# Altium schematic sheet kit_V5_borad.SchDoc
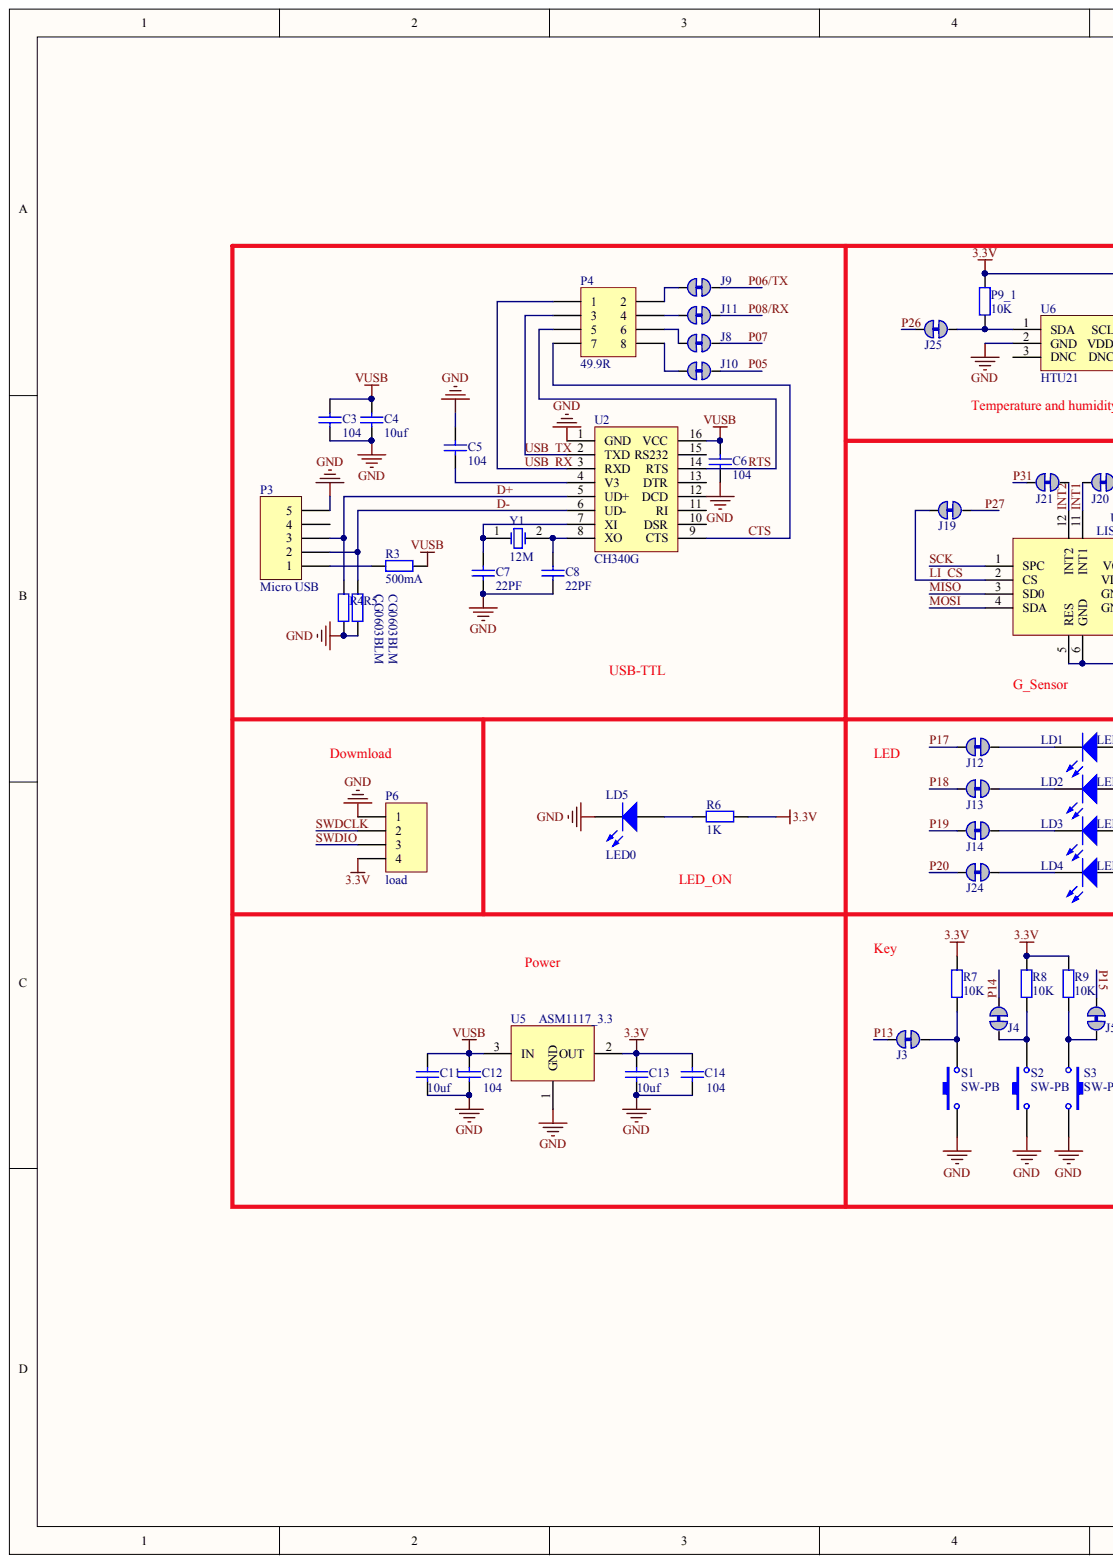
[diagram p0: kit_V5_borad.SchDoc, left]
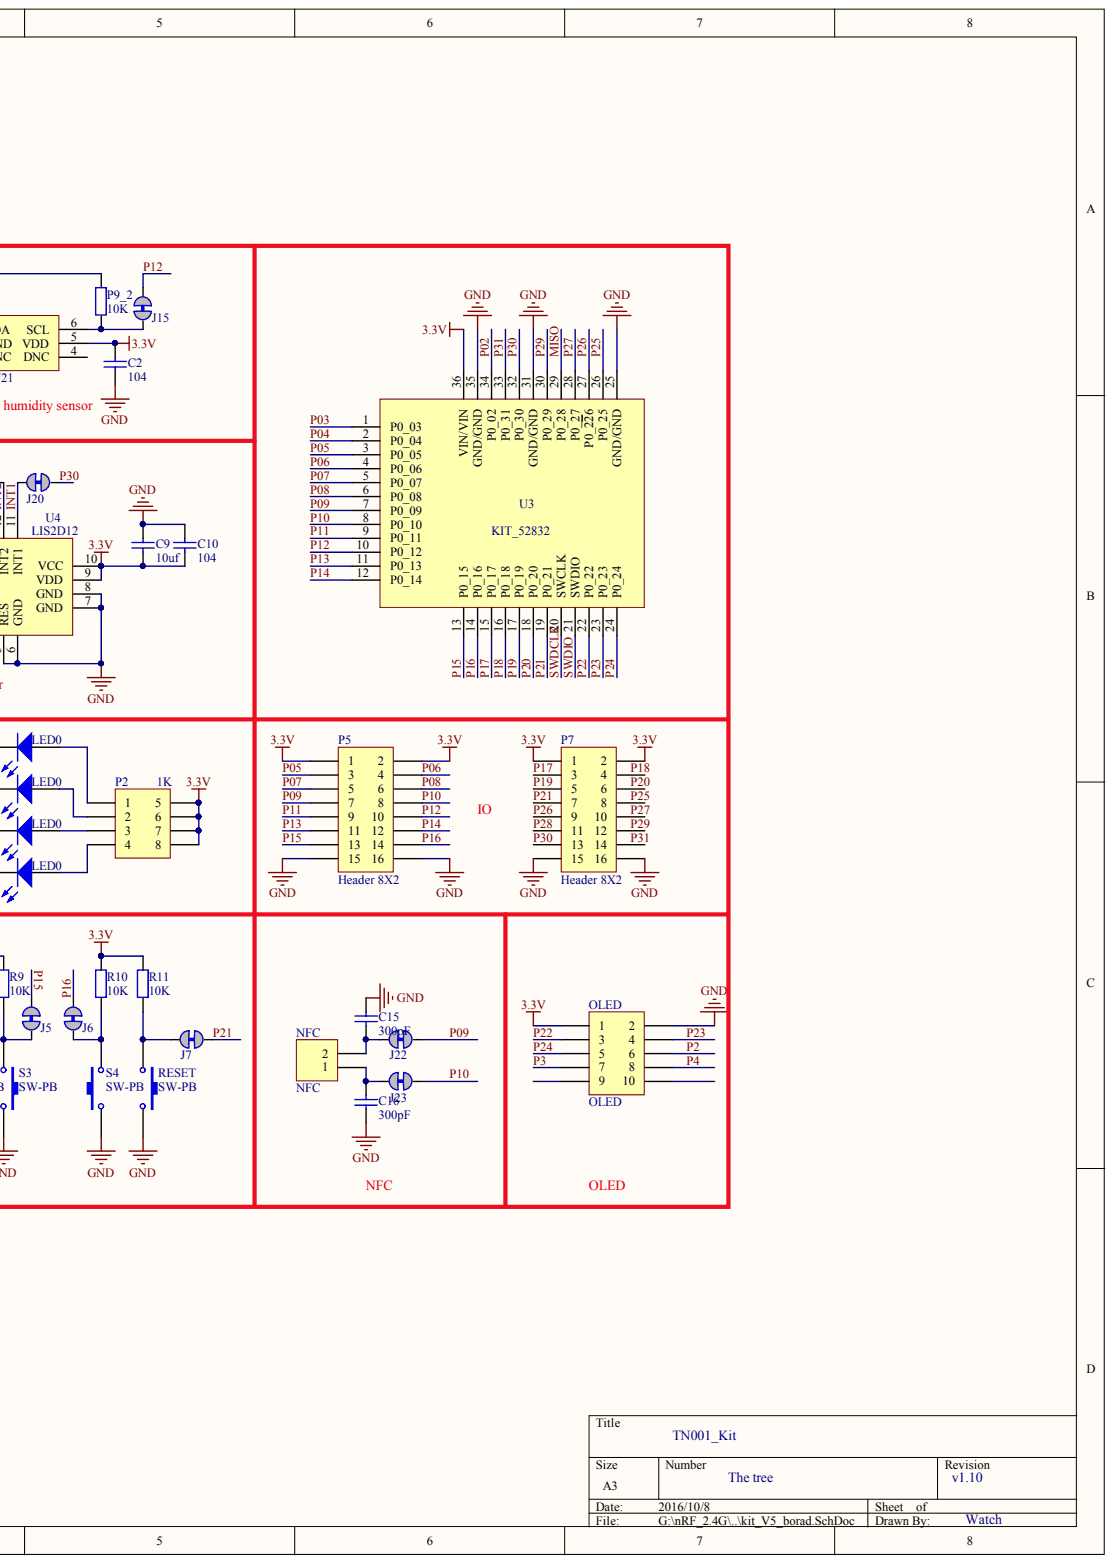
[diagram p1: kit_V5_borad.SchDoc, right]

## Parts
C2  104  [0603C]
C3  104  [0603C]
C4  10uf  [0603C]
C5  104  [0603C]
C6  104  [0603C]
C7  22PF  [0603C]
C8  22PF  [0603C]
C9  10uf  [0603C]
C10  104  [0603C]
C11  10uf  [0603C]
C12  104  [0603C]
C13  10uf  [0603C]
C14  104  [0603C]
C15  300pF  [RAD-0.3]
C16  300pF  [RAD-0.3]
J3  Component_1  (value not drawn)
J4  Component_1  (value not drawn)
J5  Component_1  (value not drawn)
J6  Component_1  (value not drawn)
J7  Component_1  (value not drawn)
J8  Component_1  (value not drawn)
J9  Component_1  (value not drawn)
J10  Component_1  (value not drawn)
J11  Component_1  (value not drawn)
J12  Component_1  (value not drawn)
J13  Component_1  (value not drawn)
J14  Component_1  (value not drawn)
J15  Component_1  (value not drawn)
J19  Component_1  (value not drawn)
J20  Component_1  (value not drawn)
J21  Component_1  (value not drawn)
J22  Component_1  (value not drawn)
J23  Component_1  (value not drawn)
J24  Component_1  (value not drawn)
J25  Component_1  (value not drawn)
LD1  LED0  [LED_0805]
LD2  LED0  [LED_0805]
LD3  LED0  [LED_0805]
LD4  LED0  [LED_0805]
LD5  LED0  [LED_0805]
NFC  NFC  [HDR1X2]
OLED  OLED  [CON5*2]
P2  1K  [0603RA]
P3  Micro USB  [USB-MICRO-5P-2]
P4  49.9R  [HDR2X4]
P5  Header 8X2  [CON8*2]
P6  load  [2.54_CON4_W]
P7  Header 8X2  [CON8*2]
P9_1  10K  [AXIAL-0.4]
P9_2  10K  [AXIAL-0.4]
R3  500mA  [1812]
R4  CG0603BLM  [0603R]
R5  CG0603BLM  [0603R]
R6  1K  [0603R]
R7  10K  [0603R]
R8  10K  [0603R]
R9  10K  [0603R]
R10  10K  [0603R]
R11  10K  [0603R]
RESET  SW-PB  [KEY_SOP_2]
S1  SW-PB  [KEY_SOP_2]
S2  SW-PB  [KEY_SOP_2]
S3  SW-PB  [KEY_SOP_2]
S4  SW-PB  [KEY_SOP_2]
U2  CH340G  [SOP16]
U3  KIT_52832
U4  LIS2D12  [LGA12_2*2]
U5  ASM1117_3.3  [SOT223]
U6  HTU21
Y1  12M  [JZ_SOP]

## Nets
3.3V: C2.1 C9.1 C10.1 C13.2 C14.2 OLED.1 P2.5 P2.6 P2.7 P2.8 P5.1 P5.2 P6.4 P7.1 P7.2 P9_1.1 P9_2.1 R6.2 R7.2 R8.2 R9.2 R10.2 R11.2 U3.36(VIN/VIN) U4.9(VDD) U4.10(VCC) U5.2 U6.5(VDD)
GND: C2.2 C3.2 C4.1 C5.2 C6.1 C7.1 C8.1 C9.2 C10.2 C11.1 C12.1 C13.1 C14.1 C15.2 C16.1 LD5.2 OLED.2 P3.5 P5.15 P5.16 P6.1 P7.15 P7.16 R4.1 R5.1 RESET.2 S1.1 S2.1 S3.2 S4.1 U2.1(GND) U3.25(GND/GND) U3.31(GND/GND) U3.35(GND/GND) U4.5(RES) U4.6(GND) U4.7(GND) U4.8(GND) U5.1 U6.2(GND)
VUSB: C3.1 C4.2 C6.2 C11.2 C12.2 R3.2 U2.16(VCC) U5.3
CTS: P4.7 U2.9(CTS)
D+: P3.3 R4.2 U2.5(UD+)
D-: P3.2 R5.2 U2.6(UD-)
INT1: J20.2 U4.11(INT1)
INT2: J21.1 U4.12(INT2)
LI_CS: J19.1 U4.2(CS)
MISO: U3.29(P0_28) U4.3(SD0)
MOSI: U4.4(SDA)
P02: U3.34(P0_02)
P2: OLED.6
P03: U3.1(P0_03)
P3: OLED.7
P04: U3.2(P0_04)
P4: OLED.8
P05: J10.1 P5.3 U3.3(P0_05)
P06: P5.4 U3.4(P0_06)
P06/TX: J9.1
P07: J8.1 P5.5 U3.5(P0_07)
P08: P5.6 U3.6(P0_08)
P08/RX: J11.1
P09: J22.1 P5.7 U3.7(P0_09)
P10: J23.1 P5.8 U3.8(P0_10)
P11: P5.9 U3.9(P0_11)
P12: J15.2 P5.10 U3.10(P0_12)
P13: J3.2 P5.11 U3.11(P0_13)
P14: J4.1 P5.12 U3.12(P0_14)
P15: J5.1 P5.13 U3.13(P0_15)
P16: J6.1 P5.14 U3.14(P0_16)
P17: J12.2 P7.3 U3.15(P0_17)
P18: J13.2 U3.16(P0_18)
P19: J14.2 P7.5 U3.17(P0_19)
P20: J24.2 U3.18(P0_20)
P21: J7.2 P7.7 U3.19(P0_21)
P22: OLED.3 U3.22(P0_22)
P23: OLED.4 U3.23(P0_23)
P24: OLED.5 U3.24(P0_24)
P25: U3.26(P0_25)
P26: J25.2 P7.9 U3.27(P0_226)
P27: J19.2 U3.28(P0_27)
P28: P7.11
P29: U3.30(P0_29)
P30: J20.1 P7.13 U3.32(P0_30)
P31: J21.2 U3.33(P0_31)
RTS: P4.5 U2.14(RTS)
SCK: U4.1(SPC)
SWDCLK: P6.2 U3.20(SWCLK)
SWDIO: P6.3 U3.21(SWDIO)
USB_RX: P4.1 U2.3(RXD)
USB_TX: P4.3 U2.2(TXD)
NetC5_1: C5.1 U2.4(V3)
NetC7_2: C7.2 U2.7(XI) Y1.1
NetC8_2: C8.2 U2.8(XO) Y1.2
NetC15_1: C15.1 J22.2 NFC.2
NetC16_2: C16.2 J23.2 NFC.1
NetJ3_1: J3.1 R7.1 S1.2
NetJ4_2: J4.2 R8.1 S2.2
NetJ5_2: J5.2 R9.1 S3.1
NetJ6_2: J6.2 R10.1 S4.2
NetJ7_1: J7.1 R11.1 RESET.1
NetJ8_2: J8.2 P4.6
NetJ9_2: J9.2 P4.2
NetJ10_2: J10.2 P4.8
NetJ11_2: J11.2 P4.4
NetJ12_1: J12.1 LD1.2
NetJ13_1: J13.1 LD2.2
NetJ14_1: J14.1 LD3.2
NetJ15_1: J15.1 P9_2.2 U6.6(SCL)
NetJ24_1: J24.1 LD4.2
NetJ25_1: J25.1 P9_1.2 U6.1(SDA)
NetLD1_1: LD1.1 P2.1
NetLD2_1: LD2.1 P2.2
NetLD3_1: LD3.1 P2.3
NetLD4_1: LD4.1 P2.4
NetLD5_1: LD5.1 R6.1
NetP3_1: P3.1 R3.1

Not connected: OLED 9 10; P3 4; P7 4 6 8 10 12 14; U2 10(DSR) 11(RI) 12(DCD) 13(DTR) 15(RS232); U6 3(DNC) 4(DNC)

## Library data of the parts
C2 C5-C14 = Cap: Capacitor; Value: 0.1uF; Component Kind: Standard; LatestRevisionDate: 17-Jul-2002; LatestRevisionNote: Re-released for DXP Platform.; Library Name: Miscellaneous Devices.IntLib; PackageDescription: Radial Cap, Thru-Hole; 2 Leads; 0.3 in Pin Spacing; PackageReference: RAD-0.3; Published: 8-Jun-2000; Signal Integrity: Cap; Simulation: CAP; Publisher: Altium Limited
C3 C4 C15 C16 = Cap: Capacitor; Value: 0.01uF; Component Kind: Standard; LatestRevisionDate: 17-Jul-2002; LatestRevisionNote: Re-released for DXP Platform.; Library Name: Miscellaneous Devices.IntLib; PackageDescription: Radial Cap, Thru-Hole; 2 Leads; 0.3 in Pin Spacing; PackageReference: RAD-0.3; Published: 8-Jun-2000; Signal Integrity: Cap; Simulation: CAP; Publisher: Altium Limited
LD1-LD5 = LED0: Typical INFRARED GaAs LED; Component Kind: Standard; LatestRevisionDate: 08-Jul-2005; LatestRevisionNote: Mech Layer 1 removed.; Library Name: Miscellaneous Devices.IntLib; PackageDescription: LED; 2 Leads; PackageReference: LED-0; Published: 8-Jun-2000; Simulation: LED0; Publisher: Altium Limited
NFC = Header 2: Header, 2-Pin; Component Kind: Standard; LatestRevisionDate: 17-Jul-2002; LatestRevisionNote: Re-released for DXP Platform.; Library Name: Miscellaneous Connectors.IntLib; Signal Integrity: Connector; Publisher: Altium Limited
OLED = Header 5X2: Header, 5-Pin, Dual row; Component Kind: Standard; LatestRevisionDate: 17-Jul-2002; LatestRevisionNote: Re-released for DXP Platform.; Library Name: Miscellaneous Connectors.IntLib; Signal Integrity: Connector; Publisher: Altium Limited
P2 = Header 4X2A: Header, 4-Pin, Dual row; Component Kind: Standard; LatestRevisionDate: 17-Jul-2002; LatestRevisionNote: Re-released for DXP Platform.; Library Name: Miscellaneous Connectors.IntLib; Signal Integrity: Connector; Publisher: Altium Limited
P3 = Header 5: Header, 5-Pin; Component Kind: Standard; LatestRevisionDate: 17-Jul-2002; LatestRevisionNote: Re-released for DXP Platform.; Library Name: Miscellaneous Connectors.IntLib; Signal Integrity: Connector; Publisher: Altium Limited
P4 = Header 4X2: Header, 4-Pin, Dual row; Component Kind: Standard; LatestRevisionDate: 17-Jul-2002; LatestRevisionNote: Re-released for DXP Platform.; Library Name: Miscellaneous Connectors.IntLib; Signal Integrity: Connector; Publisher: Altium Limited
P5 P7 = Header 8X2: Header, 8-Pin, Dual row; Component Kind: Standard; LatestRevisionDate: 17-Jul-2002; LatestRevisionNote: Re-released for DXP Platform.; Library Name: Miscellaneous Connectors.IntLib; Signal Integrity: Connector; Publisher: Altium Limited
P6 = Header 4: Header, 4-Pin; Component Kind: Standard; LatestRevisionDate: 17-Jul-2002; LatestRevisionNote: Re-released for DXP Platform.; Library Name: Miscellaneous Connectors.IntLib; Signal Integrity: Connector; Publisher: Altium Limited
P9_1 P9_2 R6-R11 = Res2: Resistor; Component Kind: Standard; LatestRevisionDate: 17-Jul-2002; LatestRevisionNote: Re-released for DXP Platform.; Library Name: Miscellaneous Devices.IntLib; PackageDescription: Axial Device, Thru-Hole; 2 Leads; 0.4 in Pin Spacing; PackageReference: AXIAL-0.4; Published: 8-Jun-2000; Signal Integrity: Res; Simulation: RESISTOR; Publisher: Altium Limited
  P9_1 P9_2 R7-R11: Value: 10K
  R6: Value: 1K
R3-R5 = Res2: Resistor; Value: 1K; Component Kind: Standard; LatestRevisionDate: 17-Jul-2002; LatestRevisionNote: Re-released for DXP Platform.; Library Name: Miscellaneous Devices.IntLib; PackageDescription: Axial Device, Thru-Hole; 2 Leads; 0.4 in Pin Spacing; PackageReference: AXIAL-0.4; Published: 8-Jun-2000; Signal Integrity: Res; Simulation: RESISTOR; Publisher: Altium Limited
RESET S1-S4 = SW-PB: Switch; Component Kind: Standard; LatestRevisionDate: 17-Jul-2002; LatestRevisionNote: Re-released for DXP Platform.; Library Name: Miscellaneous Devices.IntLib; PackageDescription: Switch; 2 Leads; PackageReference: SPST-2; Published: 24-Mar-1999; Publisher: Altium Limited
U2 = CH340: Component Kind: Standard; Library Name: watch.Lib
U5 = ASM_3.3: Component Kind: Standard; Library Name: founder.SchLib
U6 = SI7021: Component Kind: Standard; Library Name: founder.SchLib
Y1 = XTAL: Crystal Oscillator; Component Kind: Standard; LatestRevisionDate: 17-Jul-2002; LatestRevisionNote: Re-released for DXP Platform.; Library Name: Miscellaneous Devices.IntLib; PackageDescription: Crystal, Thru-Hole; 2 Leads; Body 3.1 x 8.2 mm (Dia.xH); PackageReference: R38; Published: 8-Jun-2000; Simulation: XTAL; Publisher: Altium Limited
J3-J15 J19-J25 = Component_1: Component Kind: Standard; Library Name: founder.SchLib
U3 = KIT_52832: Component Kind: Standard; Library Name: founder.SchLib
U4 = LIS2D12: Component Kind: Standard; Library Name: watch.Lib
